# Altium schematic sheet Interface.SchDoc(Interface)
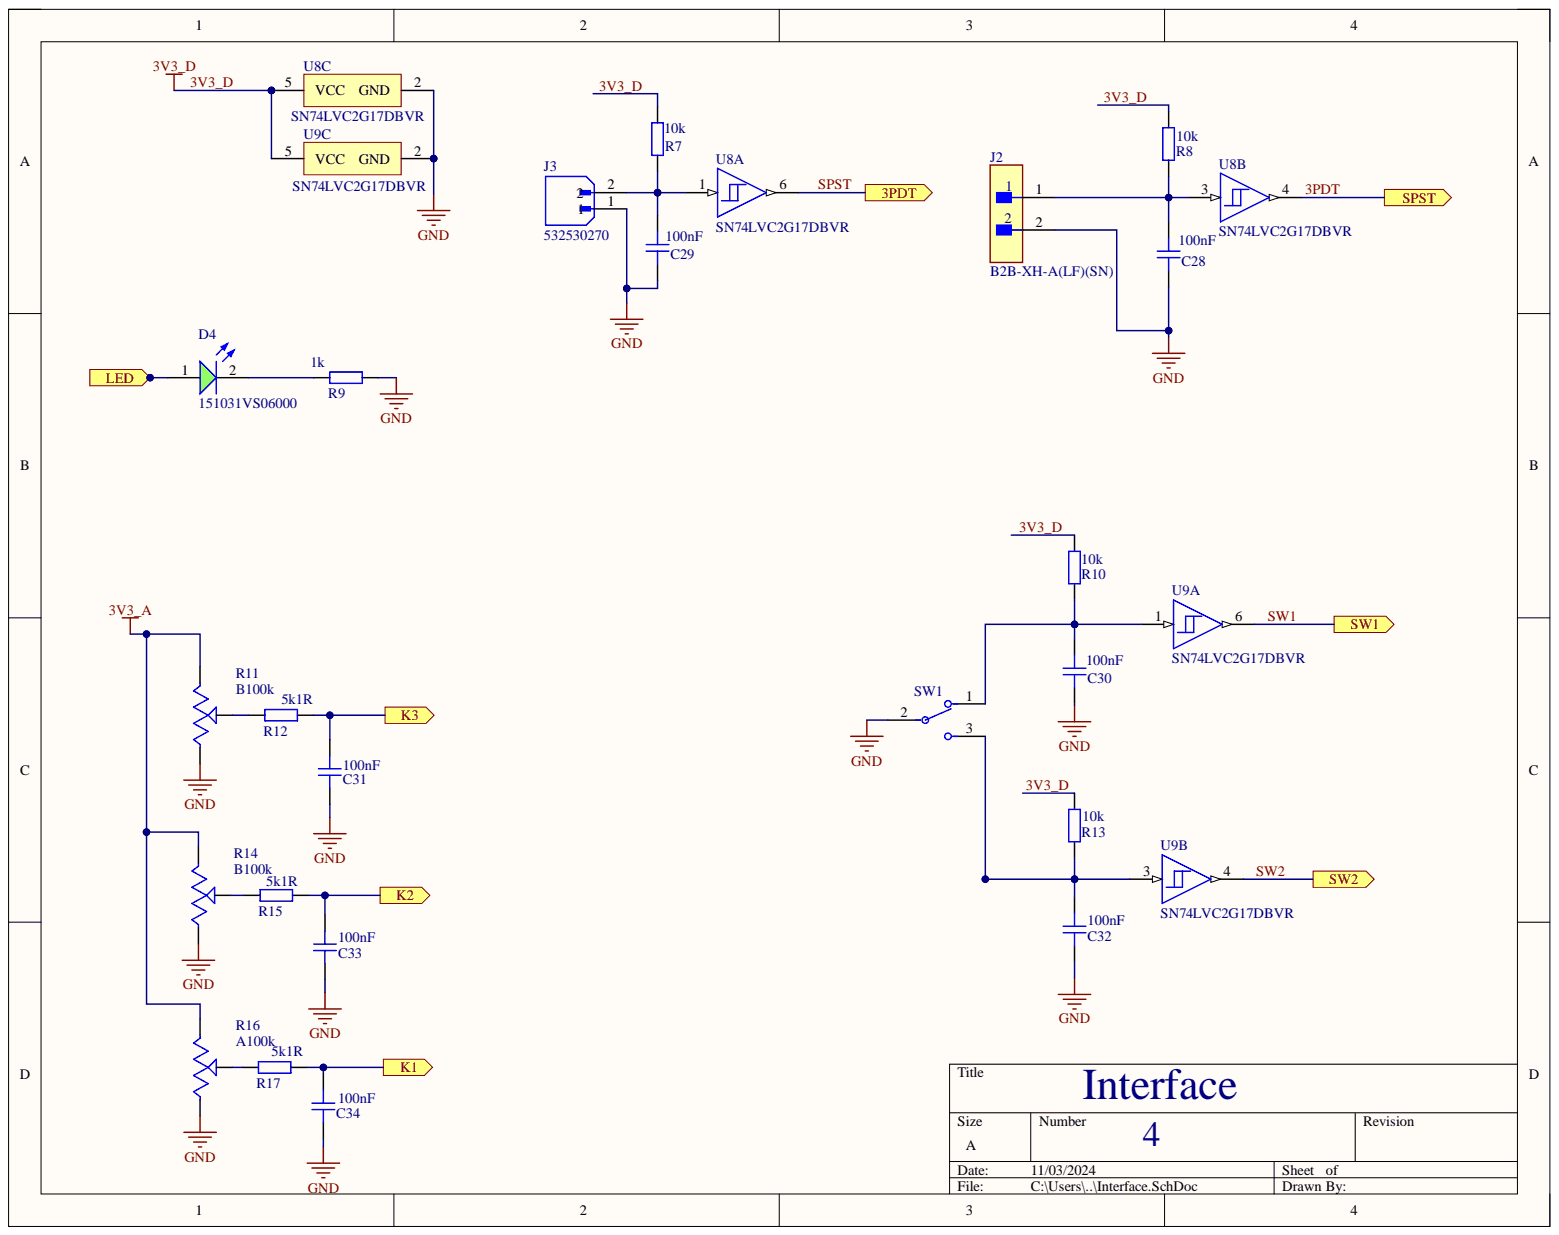
[diagram p0: Interface.SchDoc]

## Parts
C28  100nF  [CAP_0805]
C29  100nF  [CAP_0805]
C30  100nF  [CAP_0805]
C31  100nF  [CAP_0805]
C32  100nF  [CAP_0805]
C33  100nF  [CAP_0805]
C34  100nF  [CAP_0805]
D4  151031VS06000  [WL-TMRC_D3.95H5.3]
J2  B2B-XH-A(LF)(SN)  [FP-B2B-XH-A_LF_SN-MFG]
J3  532530270  [MOLEX_532530270]
R7  10k  [RES_0805]
R8  10k  [RES_0805]
R9  1k  [RES_0805]
R10  10k  [RES_0805]
R11  B100k  [POT_RD901F-40-15R1-B100K-00DL1]
R12  5k1R  [RES_0805]
R13  10k  [RES_0805]
R14  B100k  [POT_RD901F-40-15R1-B100K-00DL1]
R15  5k1R  [RES_0805]
R16  A100k  [POT_RD901F-40-15R1-B100K-00DL1]
R17  5k1R  [RES_0805]
SW1  200AWMSP1T1A1M2QE  [FP-200AWMSP1T1A1M2QE-MFG]  (value not drawn)
U8  SN74LVC2G17DBVR  [DBV0006A_N]  (parts A,B,C)
U9  SN74LVC2G17DBVR  [DBV0006A_N]  (parts A,B,C)

## Ports
3PDT, K1, K2, K3, LED, SPST, SW1, SW2

## Nets
3V3_A: R11.3 R14.3 R16.3
GND: C28.1 C29.1 C30.1 C31.1 C32.1 C33.1 C34.1 J2.2 J3.1 R9.1 R11.1 R14.1 R16.1 SW1.2 U8.2(GND) U9.2(GND)
3PDT: U8.4 ; port SPST
3V3_D: R7.2 R8.2 R10.2 R13.2 U8.5(VCC) U9.5(VCC)
K1: C34.2 R17.2 ; port K1
K2: C33.2 R15.2 ; port K2
K3: C31.2 R12.2 ; port K3
LED: D4.1 ; port LED
SPST: U8.6 ; port 3PDT
SW1: U9.6 ; port SW1
SW2: U9.4 ; port SW2
NetC28_2: C28.2 J2.1 R8.1 U8.3
NetC29_2: C29.2 J3.2 R7.1 U8.1
NetC30_2: C30.2 R10.1 SW1.1 U9.1
NetC32_2: C32.2 R13.1 SW1.3 U9.3
NetD4_2: D4.2 R9.2
NetR11_2: R11.2 R12.1
NetR14_2: R14.2 R15.1
NetR16_2: R16.2 R17.1

## Library data of the parts
C28-C34 = CMP-001-00086-1: Capacitor SMD 0805; Component Kind: Standard; Design Item ID: CAP_0805; Library Name: Projects; Source: Schematic-Lib-PhilsLab.SchLib
D4 = CMP-1488-00004-2: NexarPartId: 29717805; Color: Green; Dominant Wavelength: 568nm; Forward Current: 100mA; Forward Voltage: 2.2V; Lens Size: 3mm; Lens Style: Circular, Diffused, Round; Luminous Intensity: 30mcd; Max Reverse Voltage (DC): 5V; Peak Wavelength: 560nm; Test Current: 20mA; Viewing Angle: 60°; Case/Package: Radial; Component Kind: Standard; Height: 5.3mm; Lead Free: Lead Free; Length: 3mm; Library Name: Altium Content Vault; Max Operating Temperature: 85°C; Min Operating Temperature: -40°C; Mount: Through Hole; Packaging: Bulk; REACH SVHC: Unknown; RoHS: Compliant; Wavelength: 568nm; Width: 3mm
J2 = CMP-2000-05888-3: CONN HEADER VERT 2POS 2.5MM; Connector Type: Male Pin; Contact Resistance: 20mR; Current Rating: 3A; Insulation Resistance: 1GR; Max Voltage Rating (AC): 250V; Number of Contacts: 2; Wire/Cable Gauge: 22AWG; Color: Natural; Component Kind: Standard; Contact Material: Brass; Contact Plating: Tin; Contact Type: Male Pin; Depth: 5.75mm; Fastening Type: Detent; Housing Color: White; Housing Material: Polyamide; Lead Free: Lead Free; Lead Pitch: 2.5mm; Length: 7.4mm; Library Name: Altium Content Vault; Max Current Rating: 3A; Max Operating Temperature: 85°C; Max Voltage Rating (DC): 250V; Min Operating Temperature: -25°C; Mount: Through Hole; Number of Positions: 2; Number of Rows: 1; Orientation: Straight; Packaging: Bulk; Pitch: 2.5mm; REACH SVHC: No SVHC; Series: XH; Termination: Solder; Voltage Rating: 250V; Voltage Rating (AC): 250V
J3 = 532530270: 2.00mm Pitch, Micro-Latch PCB Header, Single Row, Vertical, Through Hole, Tin (Sn) Plating, 2 Circuits, Tray, 3.50mm PC Tail Length; Availability: Unavailable; Component Kind: Standard; Library Name: 532530270.IntLib; MP: 532530270; Package: None; Price: None
R7-R10 R12 R13 R15 R17 = CMP-009-00180-1: Resistor SMD 0805; Component Kind: Standard; Design Item ID: RES_0805; Library Name: Projects; Source: Schematic-Lib-PhilsLab.SchLib
R11 R14 R16 = RD901F-40-15R1-B100K-00DL1: Potentiometers 9mm Linear 100K Round Shaft; MF: Alpha (Taiwan); Check_prices: https://www.snapeda.com/parts/RD901F-40-15R1-B100K-00DL1/Alpha+Taiwan/view-part/?ref=eda; Component Kind: Standard; Library Name: RD901F-40-15R1-B100K-00DL1.IntLib; MP: RD901F-40-15R1-B100K-00DL1; Package: None; SnapEDA_Link: https://www.snapeda.com/parts/RD901F-40-15R1-B100K-00DL1/Alpha+Taiwan/view-part/?ref=snap
SW1 = CMP-17880-000003-1: SWITCH TOGGLE SPDT 3A 120V; Actuator Length: 10.16mm; Actuator Material: Brass; Actuator Type: Standard Round; Electrical Life: 50000Cycles; Illumination Type: Non-Illuminated; Ratings: IP67; Switch Function: On-On; Voltage Rating (DC): 28V; Component Kind: Standard; Contact Material: Copper Alloy; Contact Plating: Silver; Current Rating: 3A; Lead Free: Lead Free; Length: 8.13mm; Library Name: Altium Content Vault; Max Operating Temperature: 85°C; Min Operating Temperature: -30°C; Mount: Through Hole; Orientation: Vertical; Package Quantity: 1; Packaging: Bulk; Series: 200A; Termination: PC Pin; Throw Configuration: SPDT; Voltage Rating (AC): 120V; Width: 5.08mm
U8 U9 = CMP-0859-00225-4: Dual Schmitt-Trigger Buffer, DBV0006A, LARGE T&R; Manufacturer: Texas Instruments; 3-State Output: No; Bits(#): 2; F @ Nom Voltage(Max)(Mhz): 150; ICC @ Nom Voltage(Max)(mA): 0.01; Input Type: CMOS/TTL; Logic: True; Output Drive (IOL/IOH)(Max)(mA): 32/-32; Output Type: CMOS; Rating: Catalog; Schmitt Trigger: Yes; Sub-Family: Non-Inverting Buffer/Driver; Technology Family: LVC; tpd @ Nom Voltage(Max)(ns): 9.3,5.7,5.4,4.3; VCC(Max)(V): 5.5; VCC(Min)(V): 1.65; Voltage(Nom)(V): 1.8,2.5,3.3,5; Component Kind: Standard; DatasheetVersion: SCES381N; Generic Part Number: SN74LVC2G17; Library Name: Altium Content Vault; Operating Temperature Range(C): -40 to 125,-40 to 85; PackageReference: DBV0006A
